# Altium schematic sheet 20210515-F103CONTROL_dcmotor.SchDoc
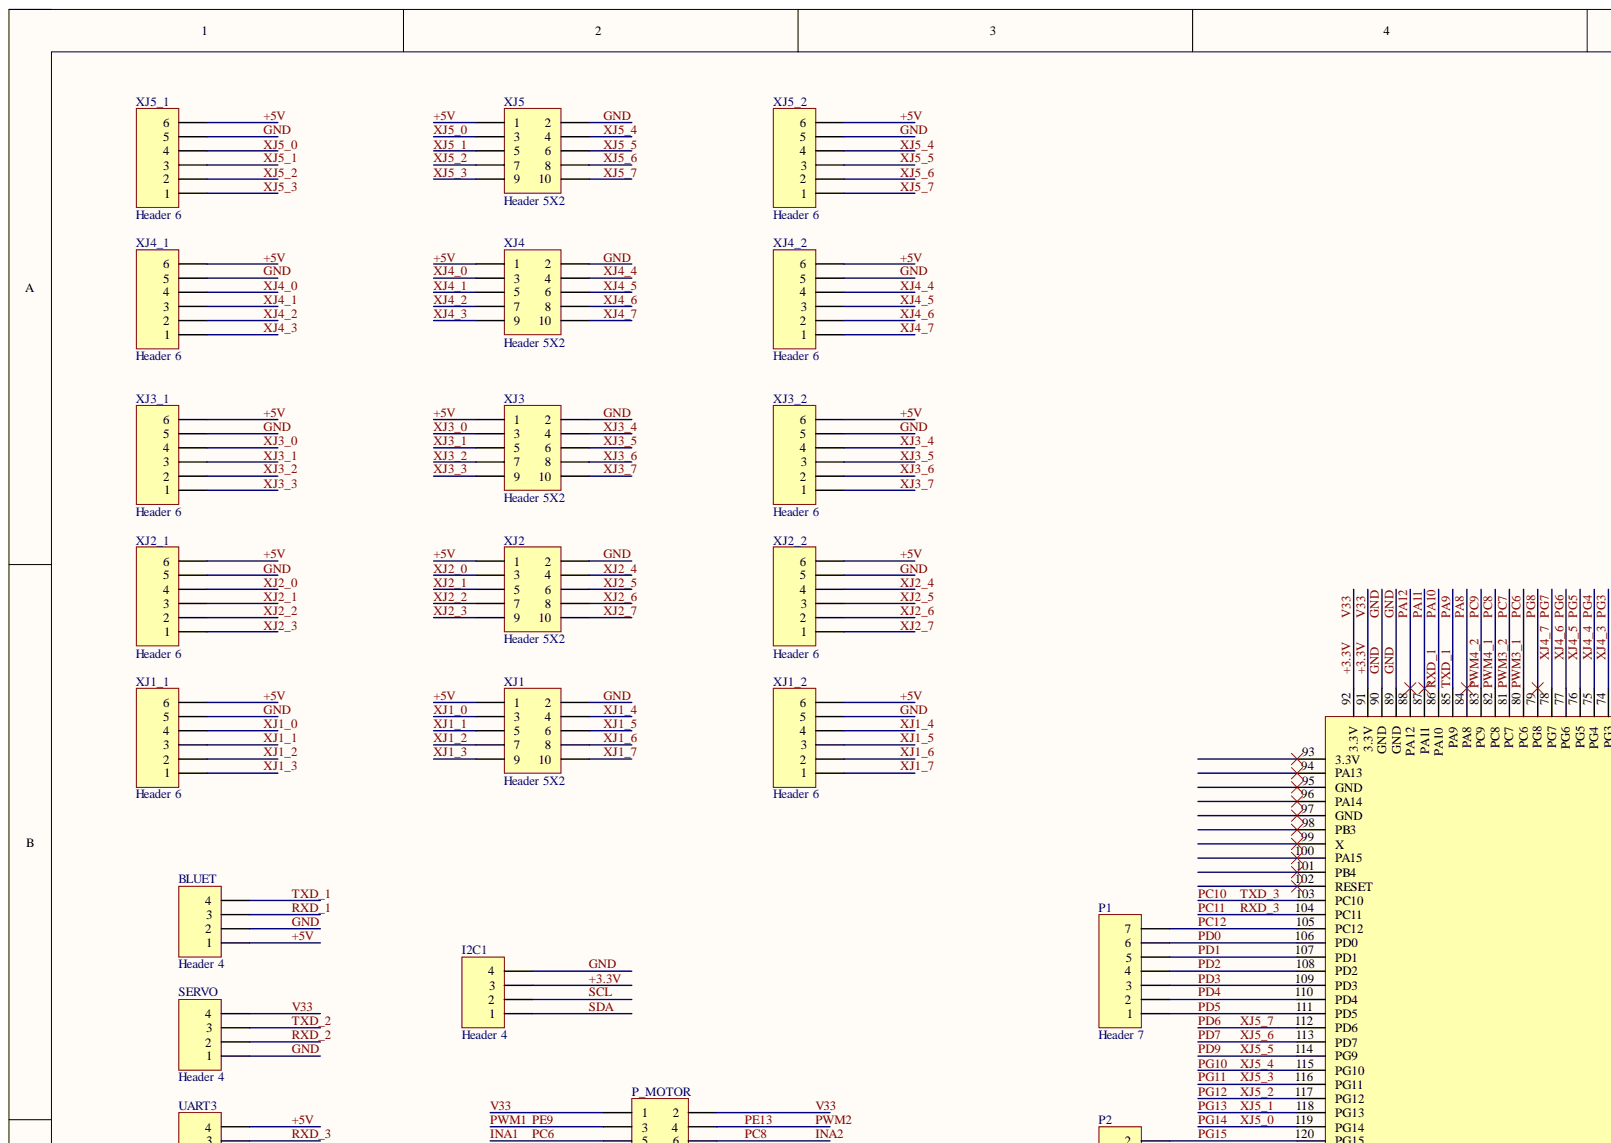
[diagram p0: 20210515-F103CONTROL_dcmotor.SchDoc, top left]
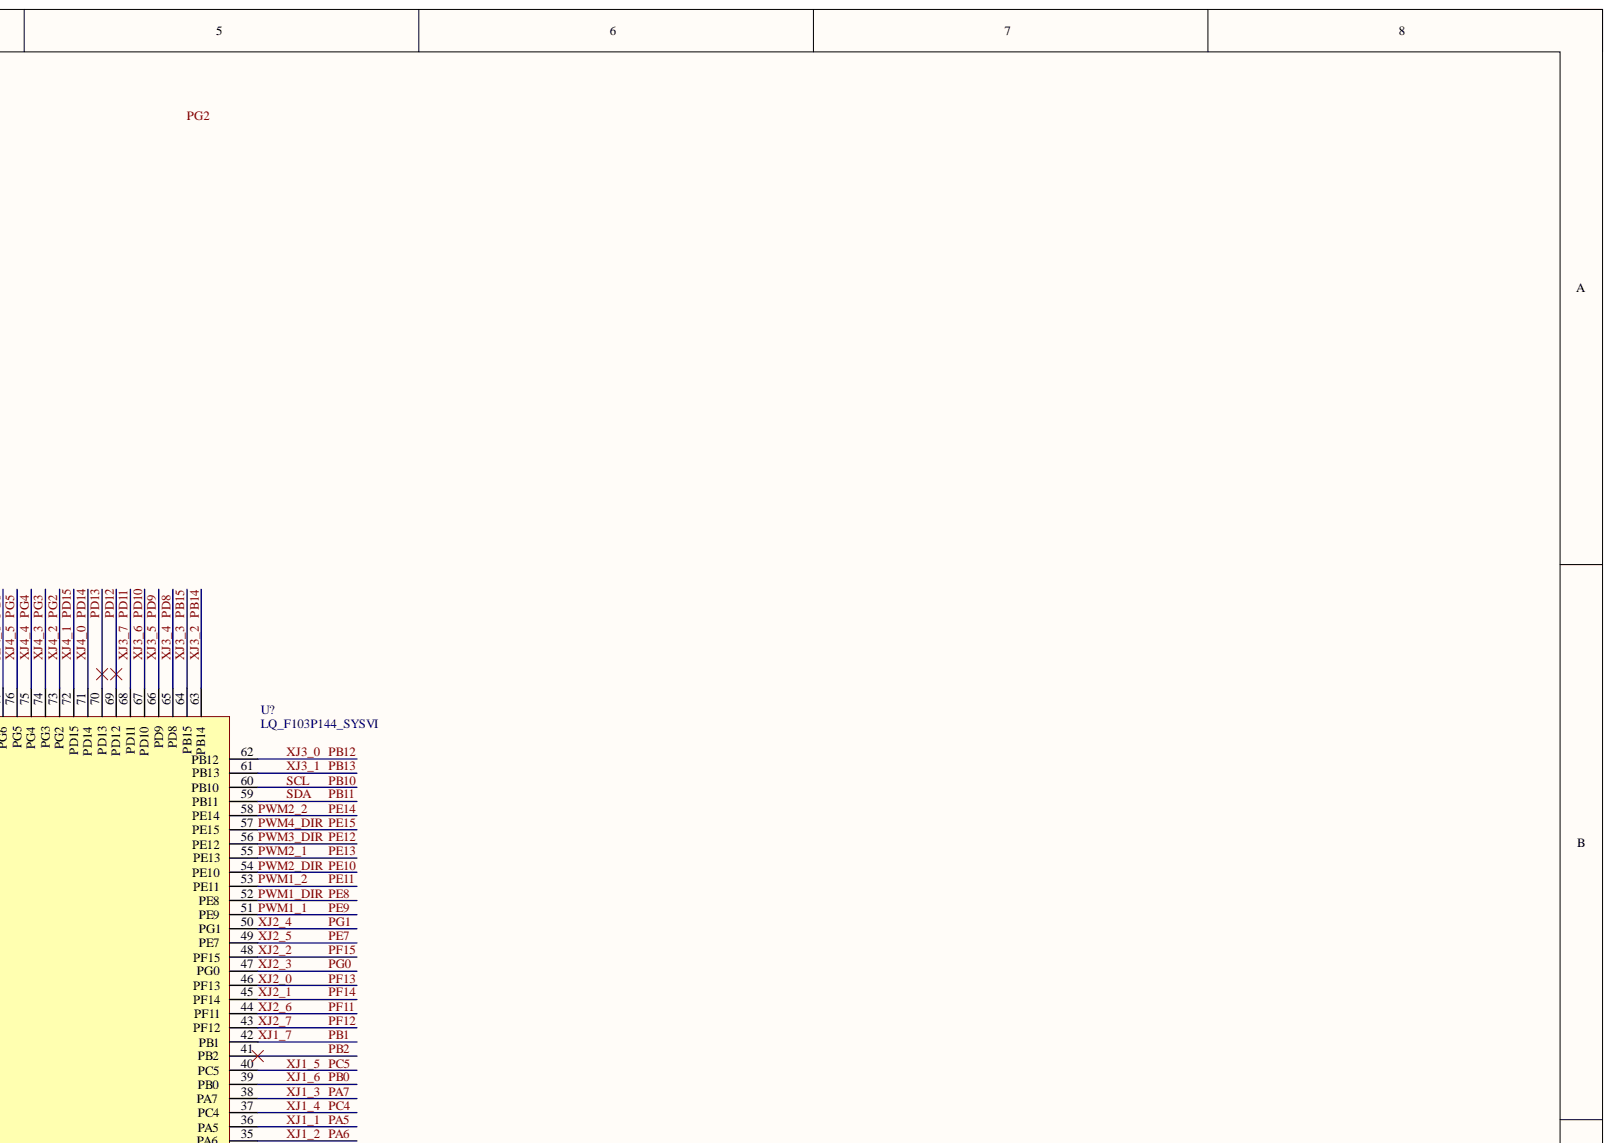
[diagram p1: 20210515-F103CONTROL_dcmotor.SchDoc, top right]
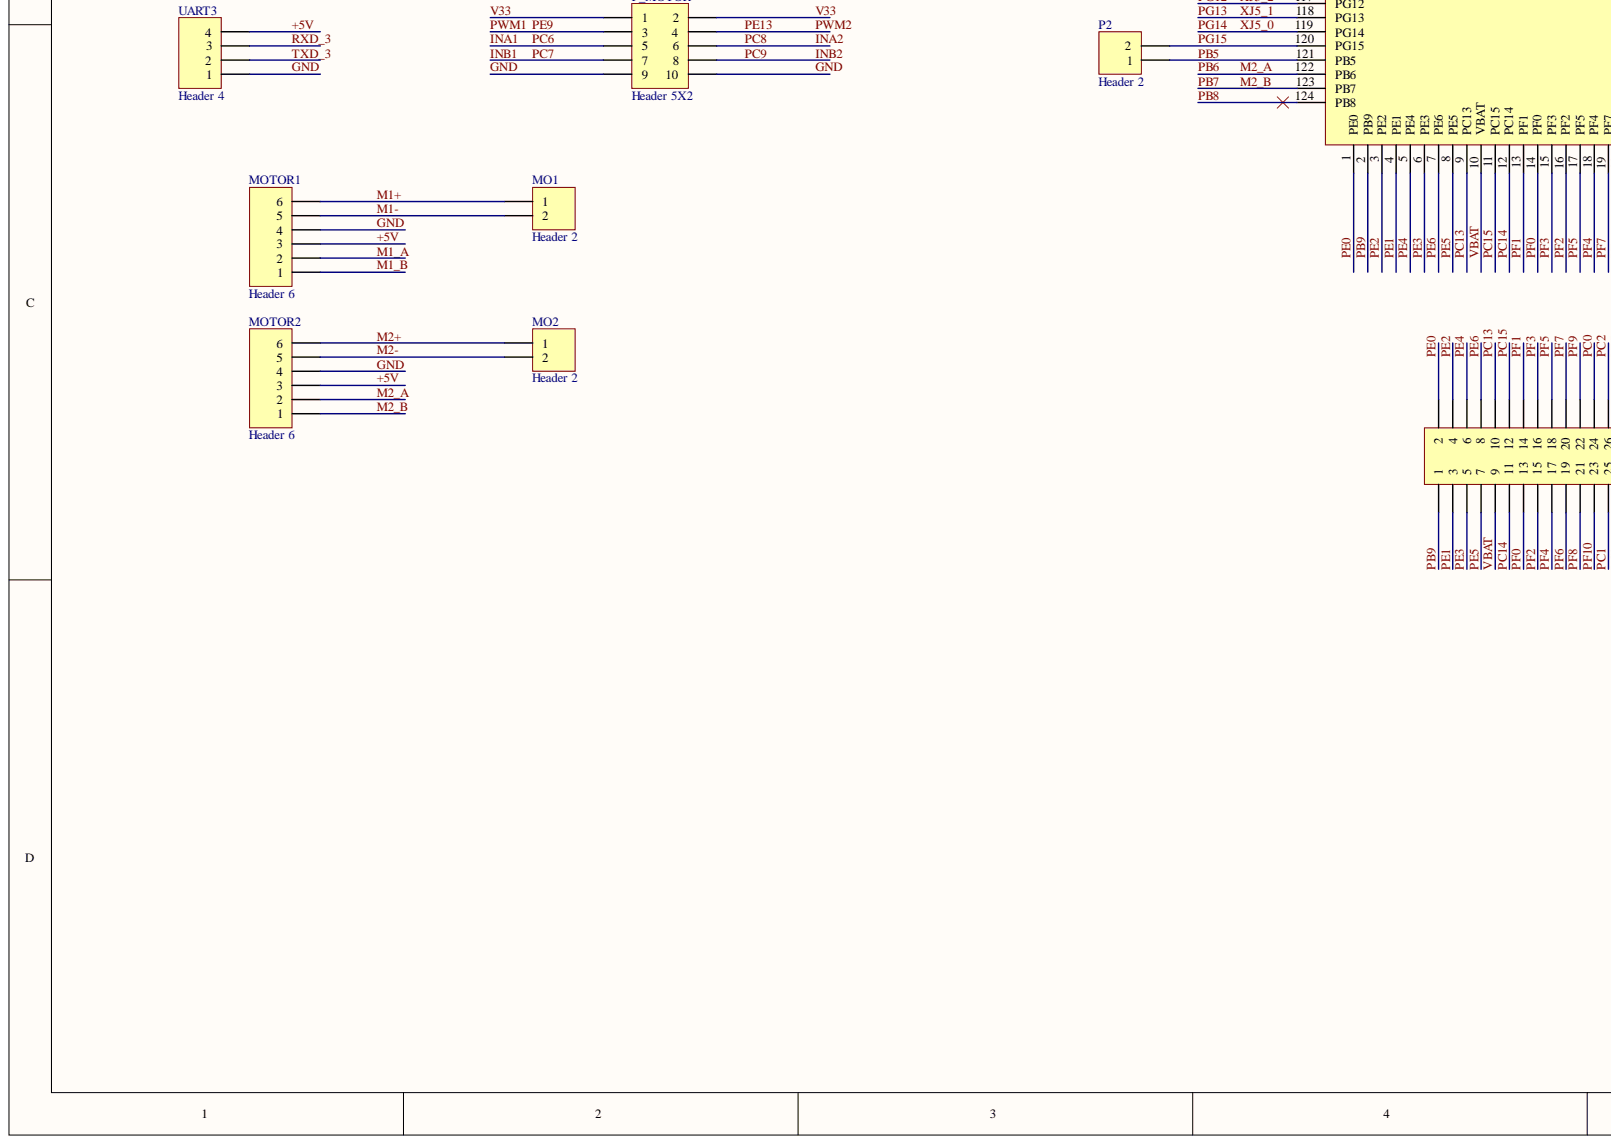
[diagram p2: 20210515-F103CONTROL_dcmotor.SchDoc, bottom left]
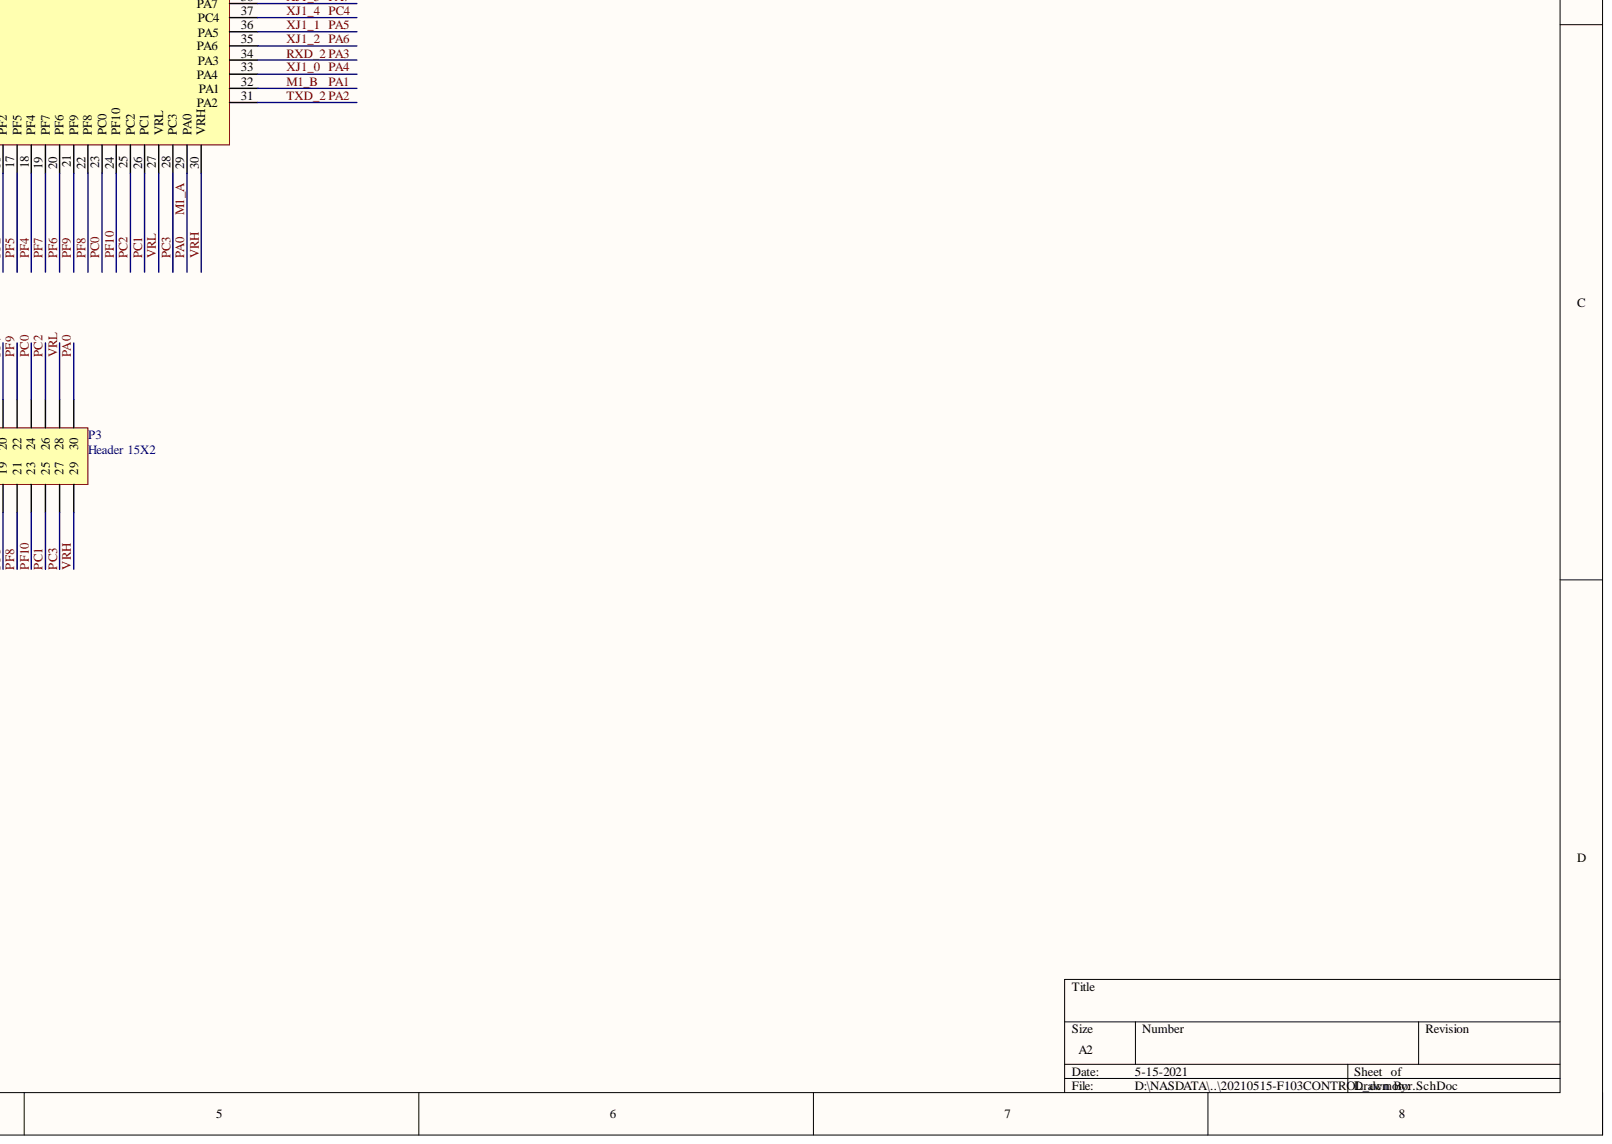
[diagram p3: 20210515-F103CONTROL_dcmotor.SchDoc, bottom right]

## Parts
BLUET  Header 4  [XH4/2.54mm/180]
I2C1  Header 4  [HDR1X4]
MO1  Header 2  [XH2/2.54mm/180]
MO2  Header 2  [XH2/2.54mm/180]
MOTOR1  Header 6  [HDR1X6]
MOTOR2  Header 6  [HDR1X6]
P1  Header 7  [HDR1X7]
P2  Header 2  [HDR1X2]
P3  Header 15X2  [HDR2X15]
P_MOTOR  Header 5X2  [HDR2X5]
SERVO  Header 4  [XH4/2.54mm/180]
U?  LQ_F103P144_SYSVI  [LQ_F103P144_SYSVI]
UART3  Header 4  [XH4/2.54mm/180]
XJ1  Header 5X2  [HDR2X5]
XJ1_1  Header 6  [PH2.0-6P]
XJ1_2  Header 6  [PH2.0-6P]
XJ2  Header 5X2  [HDR2X5]
XJ2_1  Header 6  [PH2.0-6P]
XJ2_2  Header 6  [PH2.0-6P]
XJ3  Header 5X2  [HDR2X5]
XJ3_1  Header 6  [PH2.0-6P]
XJ3_2  Header 6  [PH2.0-6P]
XJ4  Header 5X2  [HDR2X5]
XJ4_1  Header 6  [PH2.0-6P]
XJ4_2  Header 6  [PH2.0-6P]
XJ5  Header 5X2  [HDR2X5]
XJ5_1  Header 6  [PH2.0-6P]
XJ5_2  Header 6  [PH2.0-6P]

## Nets
+3.3V: I2C1.3 P_MOTOR.1 P_MOTOR.2 SERVO.4 U?.91(3.3V) U?.92(3.3V)
+5V: BLUET.1 MOTOR1.3 MOTOR2.3 UART3.4 XJ1.1 XJ1_1.6 XJ1_2.6 XJ2.1 XJ2_1.6 XJ2_2.6 XJ3.1 XJ3_1.6 XJ3_2.6 XJ4.1 XJ4_1.6 XJ4_2.6 XJ5.1 XJ5_1.6 XJ5_2.6
GND: BLUET.2 I2C1.4 MOTOR1.4 MOTOR2.4 P_MOTOR.9 P_MOTOR.10 SERVO.1 U?.89(GND) U?.90(GND) UART3.1 XJ1.2 XJ1_1.5 XJ1_2.5 XJ2.2 XJ2_1.5 XJ2_2.5 XJ3.2 XJ3_1.5 XJ3_2.5 XJ4.2 XJ4_1.5 XJ4_2.5 XJ5.2 XJ5_1.5 XJ5_2.5
INA1: P_MOTOR.5 U?.80(PC6)
INA2: P_MOTOR.6 U?.82(PC8)
INB1: P_MOTOR.7 U?.81(PC7)
INB2: P_MOTOR.8 U?.83(PC9)
M1+: MO1.1 MOTOR1.6
M1-: MO1.2 MOTOR1.5
M1_A: MOTOR1.2 P3.30 U?.29(PA0)
M1_B: MOTOR1.1 U?.32(PA1)
M2+: MO2.1 MOTOR2.6
M2-: MO2.2 MOTOR2.5
M2_A: MOTOR2.2 U?.122(PB6)
M2_B: MOTOR2.1 U?.123(PB7)
PA2: SERVO.3 U?.31(PA2)
PA3: SERVO.2 U?.34(PA3)
PA4: U?.33(PA4) XJ1.3 XJ1_1.4
PA5: U?.36(PA5) XJ1.5 XJ1_1.3
PA6: U?.35(PA6) XJ1.7 XJ1_1.2
PA7: U?.38(PA7) XJ1.9 XJ1_1.1
PA8: U?.84(PA8)
PA9: BLUET.4 U?.85(PA9)
PA10: BLUET.3 U?.86(PA10)
PA11: U?.87(PA11)
PA12: U?.88(PA12)
PB0: U?.39(PB0) XJ1.8 XJ1_2.2
PB1: U?.42(PB1) XJ1.10 XJ1_2.1
PB2: U?.41(PB2)
PB5: P2.1 U?.121(PB5)
PB8: U?.124(PB8)
PB9: P3.1 U?.2(PB9)
PB10: I2C1.2 U?.60(PB10)
PB11: I2C1.1 U?.59(PB11)
PB12: U?.62(PB12) XJ3.3 XJ3_1.4
PB13: U?.61(PB13) XJ3.5 XJ3_1.3
PB14: U?.63(PB14) XJ3.7 XJ3_1.2
PB15: U?.64(PB15) XJ3.9 XJ3_1.1
PC0: P3.24 U?.23(PC0)
PC1: P3.25 U?.26(PC1)
PC2: P3.26 U?.25(PC2)
PC3: P3.27 U?.28(PC3)
PC4: U?.37(PC4) XJ1.4 XJ1_2.4
PC5: U?.40(PC5) XJ1.6 XJ1_2.3
PC10: U?.103(PC10) UART3.2
PC11: U?.104(PC11) UART3.3
PC12: P1.7 U?.105(PC12)
PC13: P3.10 U?.9(PC13)
PC14: P3.11 U?.12(PC14)
PC15: P3.12 U?.11(PC15)
PD0: P1.6 U?.106(PD0)
PD1: P1.5 U?.107(PD1)
PD2: P1.4 U?.108(PD2)
PD3: P1.3 U?.109(PD3)
PD4: P1.2 U?.110(PD4)
PD5: P1.1 U?.111(PD5)
PD6: U?.112(PD6) XJ5.10 XJ5_2.1
PD7: U?.113(PD7) XJ5.8 XJ5_2.2
PD8: U?.65(PD8) XJ3.4 XJ3_2.4
PD9: U?.66(PD9) U?.114(PG9) XJ3.6 XJ3_2.3 XJ5.6 XJ5_2.3
PD10: U?.67(PD10) XJ3.8 XJ3_2.2
PD11: U?.68(PD11) XJ3.10 XJ3_2.1
PD12: U?.69(PD12)
PD13: U?.70(PD13)
PD14: U?.71(PD14) XJ4.3 XJ4_1.4
PD15: U?.72(PD15) XJ4.5 XJ4_1.3
PE0: P3.2 U?.1(PE0)
PE1: P3.3 U?.4(PE1)
PE2: P3.4 U?.3(PE2)
PE3: P3.5 U?.6(PE3)
PE4: P3.6 U?.5(PE4)
PE5: P3.7 U?.8(PE5)
PE6: P3.8 U?.7(PE6)
PE7: U?.49(PE7) XJ2.6 XJ2_2.3
PE8: U?.52(PE8)
PE9: P_MOTOR.3 U?.51(PE9)
PE10: U?.54(PE10)
PE11: U?.53(PE11)
PE12: U?.56(PE12)
PE13: P_MOTOR.4 U?.55(PE13)
PE14: U?.58(PE14)
PE15: U?.57(PE15)
PF0: P3.13 U?.14(PF0)
PF1: P3.14 U?.13(PF1)
PF2: P3.15 U?.16(PF2)
PF3: P3.16 U?.15(PF3)
PF4: P3.17 U?.18(PF4)
PF5: P3.18 U?.17(PF5)
PF6: P3.19 U?.20(PF6)
PF7: P3.20 U?.19(PF7)
PF8: P3.21 U?.22(PF8)
PF9: P3.22 U?.21(PF9)
PF10: P3.23 U?.24(PF10)
PF11: U?.44(PF11) XJ2.8 XJ2_2.2
PF12: U?.43(PF12) XJ2.10 XJ2_2.1
PF13: U?.46(PF13) XJ2.3 XJ2_1.4
PF14: U?.45(PF14) XJ2.5 XJ2_1.3
PF15: U?.48(PF15) XJ2.7 XJ2_1.2
PG0: U?.47(PG0) XJ2.9 XJ2_1.1
PG1: U?.50(PG1) XJ2.4 XJ2_2.4
PG2: U?.73(PG2) XJ4.7 XJ4_1.2
PG3: U?.74(PG3) XJ4.9 XJ4_1.1
PG4: U?.75(PG4) XJ4.4 XJ4_2.4
PG5: U?.76(PG5) XJ4.6 XJ4_2.3
PG6: U?.77(PG6) XJ4.8 XJ4_2.2
PG7: U?.78(PG7) XJ4.10 XJ4_2.1
PG8: U?.79(PG8)
PG10: U?.115(PG10) XJ5.4 XJ5_2.4
PG11: U?.116(PG11) XJ5.9 XJ5_1.1
PG12: U?.117(PG12) XJ5.7 XJ5_1.2
PG13: U?.118(PG13) XJ5.5 XJ5_1.3
PG14: U?.119(PG14) XJ5.3 XJ5_1.4
PG15: P2.2 U?.120(PG15)
VBAT: P3.9 U?.10(VBAT)
VRH: P3.29 U?.30(VRH)
VRL: P3.28 U?.27(VRL)

Not connected: U? 93(3.3V) 94(PA13) 95(GND) 96(PA14) 97(GND) 98(PB3) 99(X) 100(PA15) 101(PB4) 102(RESET)

## Library data of the parts
BLUET I2C1 SERVO UART3 = Header 4: Header, 4-Pin; Component Kind: Standard; LatestRevisionDate: 17-Jul-2002; LatestRevisionNote: Re-released for DXP Platform.; Library Name: Miscellaneous Connectors.IntLib; Signal Integrity: Connector; Publisher: Altium Limited
MO1 MO2 P2 = Header 2: Header, 2-Pin; Component Kind: Standard; LatestRevisionDate: 17-Jul-2002; LatestRevisionNote: Re-released for DXP Platform.; Library Name: Miscellaneous Connectors.IntLib; Signal Integrity: Connector; Publisher: Altium Limited
MOTOR1 MOTOR2 XJ1_1 XJ1_2 XJ2_1 XJ2_2 XJ3_1 XJ3_2 XJ4_1 XJ4_2 XJ5_1 XJ5_2 = Header 6: Header, 6-Pin; Component Kind: Standard; LatestRevisionDate: 17-Jul-2002; LatestRevisionNote: Re-released for DXP Platform.; Library Name: Miscellaneous Connectors.IntLib; Signal Integrity: Connector; Publisher: Altium Limited
P1 = Header 7: Header, 7-Pin; Component Kind: Standard; LatestRevisionDate: 17-Jul-2002; LatestRevisionNote: Re-released for DXP Platform.; Library Name: Miscellaneous Connectors.IntLib; Signal Integrity: Connector; Publisher: Altium Limited
P3 = Header 15X2: Header, 15-Pin, Dual row; Component Kind: Standard; LatestRevisionDate: 17-Jul-2002; LatestRevisionNote: Re-released for DXP Platform.; Library Name: Miscellaneous Connectors.IntLib; Signal Integrity: Connector; Publisher: Altium Limited
P_MOTOR XJ1-XJ5 = Header 5X2: Header, 5-Pin, Dual row; Component Kind: Standard; LatestRevisionDate: 17-Jul-2002; LatestRevisionNote: Re-released for DXP Platform.; Library Name: Miscellaneous Connectors.IntLib; Signal Integrity: Connector; Publisher: Altium Limited
U? = LQ_F103P144_SYSVI: Component Kind: Standard; Description: LQ_F103P144_SYSVI; Library Name: SCH_MY.SCHLIB
